# Altium schematic sheet Sheet4.SchDoc
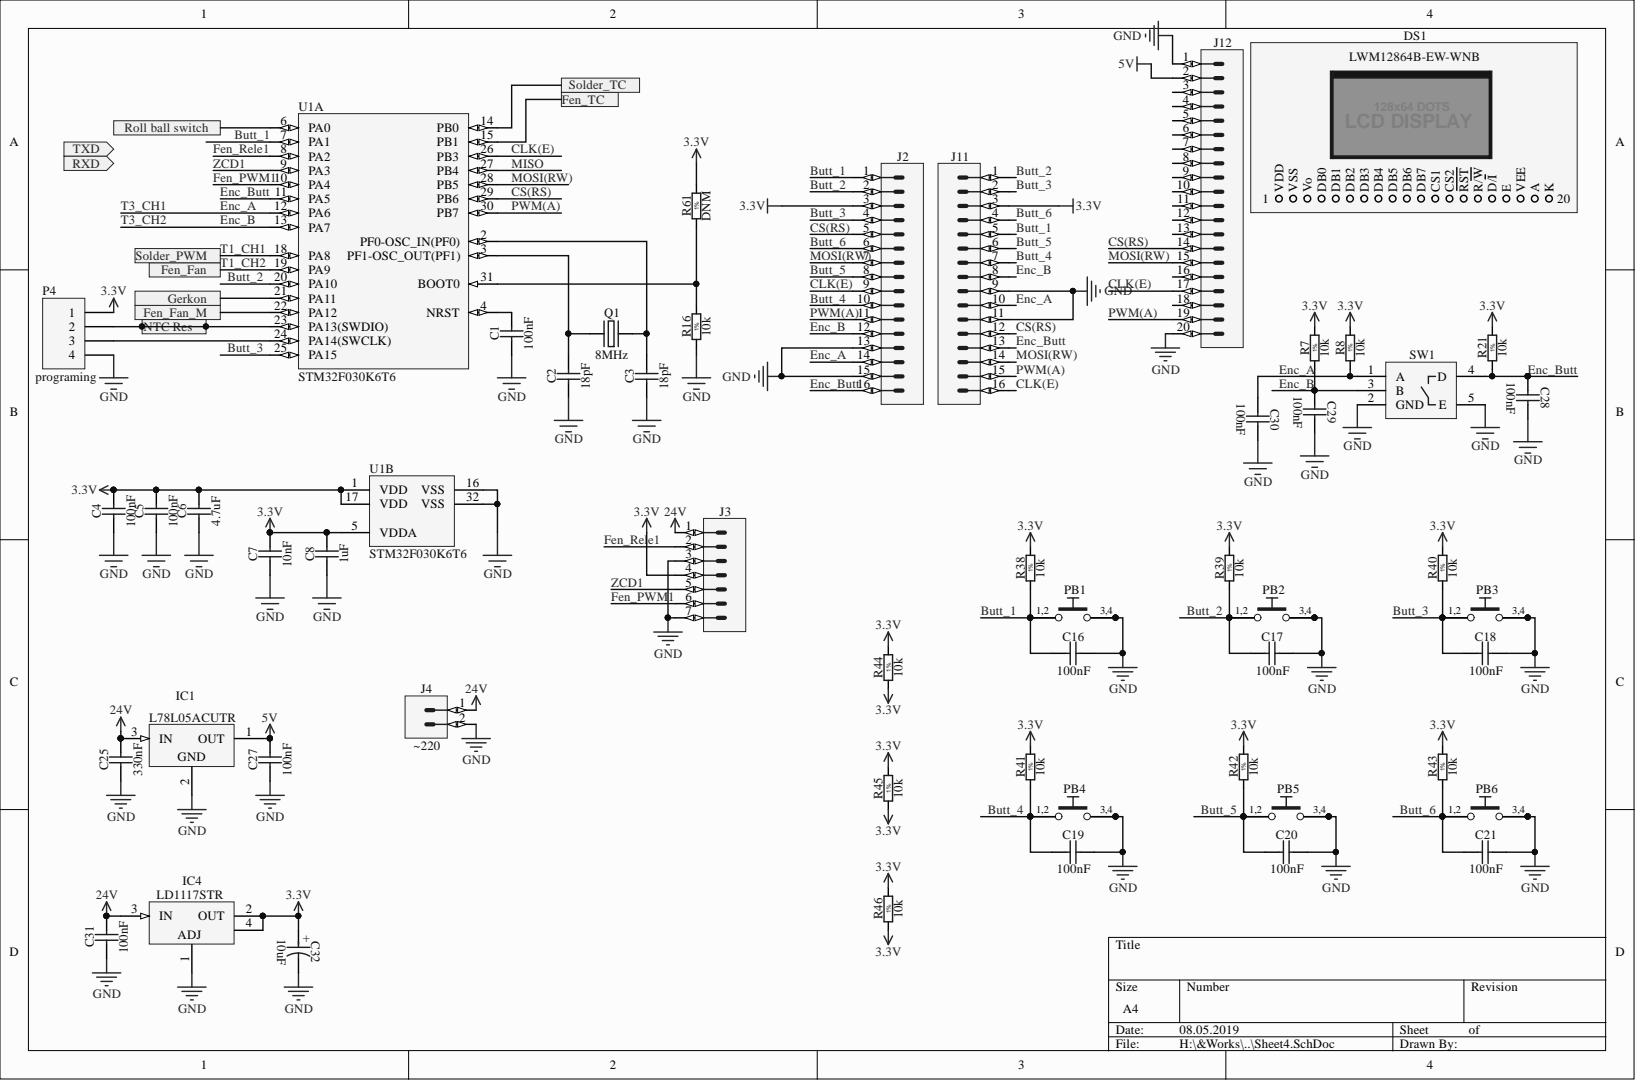
[diagram p0: Sheet4.SchDoc]

## Parts
C1  100nF  [CAPC1608X87N]
C2  18pF  [CAPC2012X135N]
C3  18pF  [CAPC2012X135N]
C4  100nF  [CAPC1608X87N]
C5  100nF  [CAPC1608X87N]
C6  4.7uF  [CAPC1608X87N]
C7  10nF  [CAPC1608X87N]
C8  1uF  [CAPC1608X87N]
C16  100nF  [CAPC2012X135N]
C17  100nF  [CAPC2012X135N]
C18  100nF  [CAPC2012X135N]
C19  100nF  [CAPC2012X135N]
C20  100nF  [CAPC2012X135N]
C21  100nF  [CAPC2012X135N]
C25  330nF  [CAPC2012X145N]
C27  100nF  [CAPC2013X145N]
C28  100nF  [CAPC2012X135N]
C29  100nF  [CAPC2012X135N]
C30  100nF  [CAPC2012X135N]
C31  100nF  [CAPC2013X145N]
C32  10uF  [CAPAE430X540N]
DS1  LWM12864B-EW-WNB  [DS_DENSITRON_LWM12864B-EW-WNB]
IC1  L78L05ACUTR  [SOT89]
IC4  LD1117STR  [SOT230P700X180-4N]
J2  Header 16  [3M_N2516-6002RB]  (value not drawn)
J3  KK®  [MOLEX_22-04-1071]  (value not drawn)
J4  ~220  [PHOENIX_1755736]
J11  Header 16  [3M_N2516-6002RB]  (value not drawn)
J12  =Family  [HARWIN_D01-9922046]
P4  programing  [HDR1X4]
PB1  Push Button  [PB_TYCO_FSM2JSMA]  (value not drawn)
PB2  Push Button  [PB_TYCO_FSM2JSMA]  (value not drawn)
PB3  Push Button  [PB_TYCO_FSM2JSMA]  (value not drawn)
PB4  Push Button  [PB_TYCO_FSM2JSMA]  (value not drawn)
PB5  Push Button  [PB_TYCO_FSM2JSMA]  (value not drawn)
PB6  Push Button  [PB_TYCO_FSM2JSMA]  (value not drawn)
Q1  8MHz  [XTAL_CITIZEN_HCM49]
R7  10k  [RESC2012X60N]
R8  10k  [RESC2012X60N]
R16  10k  [RESC1608X55N]
R21  10k  [RESC2012X60N]
R38  10k  [RESC2012X60N]
R39  10k  [RESC2012X60N]
R40  10k  [RESC2012X60N]
R41  10k  [RESC2012X60N]
R42  10k  [RESC2012X60N]
R43  10k  [RESC2012X60N]
R44  10k  [RESC2012X60N]
R45  10k  [RESC2012X60N]
R46  10k  [RESC2012X60N]
R61  DNM  [RESC2012X60N]
SW1  Encoder  [Encoder_DIP]  (value not drawn)
U1  STM32F030K6T6  [STM-LQFP32_N]  (parts A,B)

## Ports
Fen_Fan, Fen_Fan_M, Fen_TC, Gerkon, NTC Res, Roll ball switch, RXD, Solder_PWM, Solder_TC, TXD

## Nets
3.3V: C4.2 C5.2 C6.2 C7.2 C8.2 C32.1 IC4.2(OUT) IC4.4 J2.3 J3.4 J11.3 P4.1 R7.2 R8.2 R21.2 R38.2 R39.2 R40.2 R41.2 R42.2 R43.2 R44.1 R44.2 R45.1 R45.2 R46.1 R46.2 R61.2 U1.1(VDD) U1.5(VDDA) U1.17(VDD)
5V: C27.2 IC1.1 J12.2
24V: C25.2 C31.2 IC1.3 IC4.3(IN) J3.1 J4.1
GND: C1.1 C2.1 C3.1 C4.1 C5.1 C6.1 C7.1 C8.1 C16.2 C17.2 C18.2 C19.2 C20.2 C21.2 C25.1 C27.1 C28.2 C29.2 C30.2 C31.1 C32.2 IC1.2 IC4.1 J2.13 J2.15 J3.3 J3.7 J4.2 J11.9 J11.11 J12.1 J12.20 P4.4 PB1.3 PB1.4 PB2.3 PB2.4 PB3.3 PB3.4 PB4.3 PB4.4 PB5.3 PB5.4 PB6.3 PB6.4 R16.1 SW1.2(GND) SW1.5(E) U1.16(VSS) U1.32(VSS)
Butt_1: C16.1 J2.1 J11.5 PB1.1 PB1.2 R38.1 U1.7(PA1)
Butt_2: C17.1 J2.2 J11.1 PB2.1 PB2.2 R39.1 U1.20(PA10)
Butt_3: C18.1 J2.4 J11.2 PB3.1 PB3.2 R40.1 U1.25(PA15)
Butt_4: C19.1 J2.10 J11.7 PB4.1 PB4.2 R41.1
Butt_5: C20.1 J2.8 J11.6 PB5.1 PB5.2 R42.1
Butt_6: C21.1 J2.6 J11.4 PB6.1 PB6.2 R43.1
CLK(E): J2.9 J11.16 J12.17 U1.26(PB3)
CS(RS): J2.5 J11.12 J12.14 U1.29(PB6)
Enc_A: C30.1 J2.14 J11.10 R8.1 SW1.1(A) U1.12(PA6)
Enc_B: C29.1 J2.12 J11.8 R7.1 SW1.3(B) U1.13(PA7)
Enc_Butt: C28.1 J2.16 J11.13 R21.1 SW1.4(D) U1.11(PA5)
Fen_Fan_M: U1.22(PA12) ; port Fen_Fan_M
Fen_PWM1: J3.6 U1.10(PA4)
Fen_Rele1: J3.2 U1.8(PA2)
Fen_TC: U1.15(PB1) ; port Fen_TC
Gerkon: U1.21(PA11) ; port Gerkon
MISO: U1.27(PB4)
MOSI(RW): J2.7 J11.14 J12.15 U1.28(PB5)
NTC Res: P4.2 U1.23(PA13(SWDIO)) ; port NTC Res
PWM(A): J2.11 J11.15 J12.19 U1.30(PB7)
Roll ball switch: U1.6(PA0) ; port Roll ball switch
Solder_TC: U1.14(PB0) ; port Solder_TC
T1_CH1: U1.18(PA8) ; port Solder_PWM
T1_CH2: U1.19(PA9) ; port Fen_Fan
ZCD1: J3.5 U1.9(PA3)
NetC1_2: C1.2 U1.4(NRST)
NetC2_2: C2.2 Q1.1 U1.3(PF1-OSC_OUT(PF1))
NetC3_2: C3.2 Q1.2 U1.2(PF0-OSC_IN(PF0))
NetP4_3: P4.3 U1.24(PA14(SWCLK))
NetR16_2: R16.2 R61.1 U1.31(BOOT0)
N00097: ; port RXD
N00098: ; port TXD

Not connected: J12 3 4 5 6 7 8 9 10 11 12 13 16 18

## Library data of the parts
C1 C4 C5 = CC0603_100NF_50V_10%_X7R: Non-polarised capacitor; Manufacturer: GENERIC; Manufacturer1 Part Number: 223858615649; Part Description: SMD Multilayer Chip Ceramic Capacitor; Database Table Name: Capacitors SMD; TC: X7R; Tolerance: ±10%; Voltage: 50V; Case: 0603; Component Kind: Standard; Component Type: Standard; CreateDate: 03.12.2007; HelpURL: \\cern.ch\dfs\Applications\Altium\Datasheets\CC0603_X7R_PHYCOMP.pdf; LatestRevisionDate: 03.12.2007; Library Name: Capacitors.DbLib; Manufacturer1 ComponentHeight: 0.87mm; Manufacturer1 Example: PHYCOMP; Manufacturer Part Number: CC0603_100NF_50V_10%_X7R; Mounted: Yes; Part Number: CC0603_100NF_50V_10%_X7R; Pin Count: 2; Sense: No; SMD: Yes; Socket: No; Status: Preferred; Value: 100nF; Author: CERN DEM JLC
C2 C3 = CC0805_18PF_50V_5%_NP0: Non-polarised capacitor; Manufacturer: GENERIC; Manufacturer1 Part Number: 223886115189; Part Description: SMD Multilayer Chip Ceramic Capacitor; Database Table Name: Capacitors SMD; TC: C0G/NP0; Tolerance: ±5%; Voltage: 50V; Case: 0805; Component Kind: Standard; Component Type: Standard; CreateDate: 03.12.2007; HelpURL: \\cern.ch\dfs\Applications\Altium\Datasheets\CC0805_NP0_PHYCOMP.pdf; LatestRevisionDate: 03.12.2007; Library Name: Capacitors.DbLib; Manufacturer1 ComponentHeight: 1.35mm; Manufacturer1 Example: PHYCOMP; Manufacturer Part Number: CC0805_18PF_50V_5%_NP0; Mounted: Yes; Part Number: CC0805_18PF_50V_5%_NP0; Pin Count: 2; Sense: No; SMD: Yes; Socket: No; Status: Preferred; Value: 18pF; Author: CERN DEM JLC
C6 = CC0603_4.7UF_6.3V_10%_X5R: Non-polarised capacitor; Manufacturer: GENERIC; Manufacturer1 Part Number: 225520613672; Part Description: SMD Multilayer Chip Ceramic Capacitor; Database Table Name: Capacitors SMD; TC: X5R; Tolerance: ±10%; Voltage: 6.3V; Case: 0603; Component Kind: Standard; Component Type: Standard; CreateDate: 03.12.2007; HelpURL: \\cern.ch\dfs\Applications\Altium\Datasheets\CC0805_X5R_PHYCOMP_HC.pdf; LatestRevisionDate: 03.12.2007; Library Name: Capacitors.DbLib; Manufacturer1 ComponentHeight: 0.87mm; Manufacturer1 Example: PHYCOMP; Manufacturer Part Number: CC0603_4.7uF_6.3V_10%_X5R; Mounted: Yes; Part Number: CC0603_4.7UF_6.3V_10%_X5R; Pin Count: 2; Sense: No; SMD: Yes; Socket: No; Status: Preferred; Value: 4.7uF; Author: CERN DEM JLC
C7 = CC0603_10NF_50V_10%_X7R: Non-polarised capacitor; Manufacturer: GENERIC; Manufacturer1 Part Number: 223858615636; Part Description: SMD Multilayer Chip Ceramic Capacitor; Database Table Name: Capacitors SMD; TC: X7R; Tolerance: ±10%; Voltage: 50V; Case: 0603; Component Kind: Standard; Component Type: Standard; CreateDate: 03.12.2007; HelpURL: \\cern.ch\dfs\Applications\Altium\Datasheets\CC0603_X7R_PHYCOMP.pdf; LatestRevisionDate: 03.12.2007; Library Name: Capacitors.DbLib; Manufacturer1 ComponentHeight: 0.87mm; Manufacturer1 Example: PHYCOMP; Manufacturer Part Number: CC0603_10NF_50V_10%_X7R; Mounted: Yes; Part Number: CC0603_10NF_50V_10%_X7R; Pin Count: 2; Sense: No; SMD: Yes; Socket: No; Status: Preferred; Value: 10nF; Author: CERN DEM JLC
C8 = CC0603_1UF_16V_10%_X7R: Non-polarised capacitor; Manufacturer: GENERIC; Manufacturer1 Part Number: 223878615663; Part Description: SMD Multilayer Chip Ceramic Capacitor; Database Table Name: Capacitors SMD; TC: X7R; Tolerance: ±10%; Voltage: 16V; Case: 0603; Component Kind: Standard; Component Type: Standard; CreateDate: 03.12.2007; HelpURL: \\cern.ch\dfs\Applications\Altium\Datasheets\CC0603_X7R_PHYCOMP.pdf; LatestRevisionDate: 17.04.2015; Library Name: Capacitors.DbLib; Manufacturer1 ComponentHeight: 0.9mm; Manufacturer1 Example: YAGEO PHYCOMP; Manufacturer Part Number: CC0603_1uF_16V_10%_X7R; Mounted: Yes; Part Number: CC0603_1UF_16V_10%_X7R; Pin Count: 2; Sense: No; SMD: Yes; Socket: No; Status: None; Value: 1uF; Author: CERN DEM JLC
C16-C21 C28-C30 = CC0805_100NF_50V_10%_X7R: Non-polarised capacitor; Manufacturer: GENERIC; Manufacturer1 Part Number: C2012X7R1H104K085AA; Part Description: SMD Multilayer Chip Ceramic Capacitor; Database Table Name: Capacitors SMD; TC: X7R; Tolerance: ±10%; Voltage: 50V; Case: 0805; Component Kind: Standard; Component Type: Standard; CreateDate: 03.12.2007; HelpURL: \\cern.ch\dfs\Applications\Altium\Datasheets\CC0805_X7R_TDK_C.pdf; LatestRevisionDate: 22.04.2015; Library Name: Capacitors.DbLib; Manufacturer1 ComponentHeight: 1mm; Manufacturer1 Example: TDK; Manufacturer Part Number: CC0805_100NF_50V_10%_X7R; Mounted: Yes; Part Number: CC0805_100NF_50V_10%_X7R; Pin Count: 2; Sense: No; SMD: Yes; Socket: No; Status: Preferred; Value: 100nF; Author: CERN DEM JLC
C25 = CC0805_330NF_50V_20%_X5R: Non-polarised capacitor; Manufacturer: GENERIC; Manufacturer1 Part Number: C2012X5R1H334M125AA; Part Description: SMD Multilayer Chip Ceramic Capacitor; Database Table Name: Capacitors SMD; TC: X5R; Tolerance: ±20%; Voltage: 50V; Case: 0805; Component Kind: Standard; Component Type: Standard; CreateDate: 16.09.2016; HelpURL: \\cern.ch\dfs\Applications\Altium\Datasheets\CC0805_X5R_TDK_C.pdf; LatestRevisionDate: 16.09.2016; Library Name: Capacitors.DbLib; Manufacturer1 ComponentHeight: 1.45mm; Manufacturer1 Example: TDK; Manufacturer Part Number: CC0805_330NF_50V_20%_X5R; Mounted: Yes; Part Number: CC0805_330NF_50V_20%_X5R; Pin Count: 2; Sense: No; SMD: Yes; Socket: No; Status: Preferred; Value: 330nF; Author: CERN DEM MR
C27 C31 = CC0805_100NF_50V_10%_X7R_VISHAY_VJ_NON-MAGNETIC: Non-polarised capacitor; Manufacturer: VISHAY VITRAMON; Manufacturer Part Number: VJ0805Y104KCAA; Part Description: Surface Mount Multilayer Ceramic Chip Capacitors for Non-Magnetic Applications (IR Reflow Soldering); Database Table Name: Capacitors SMD; TC: X7R; Tolerance: ±10%; Voltage: 50V; Case: 0805; ComponentHeight: 1.45mm; Component Kind: Standard; Component Type: Standard; CreateDate: 22.06.2016; HelpURL: \\cern.ch\dfs\Applications\Altium\Datasheets\CC0805_VISHAY_VJ_NON-MAGNETIC.pdf; LatestRevisionDate: 22.06.2016; Library Name: Capacitors.DbLib; Mounted: Yes; Part Number: CC0805_100NF_50V_10%_X7R_VISHAY_VJ_NON-MAGNETIC; Pin Count: 2; Sense: No; SMD: Yes; Socket: No; Status: None; Value: 100nF; Author: CERN DEM MR
C32 = CAE430-540_10UF_16V_20%: Polarised capacitor; Manufacturer: PANASONIC; Manufacturer Part Number: EEEFK1C100AR; Part Description: Aluminum Electrolytic SMT Capacitor; Database Table Name: Capacitors SMD; Tolerance: ±20%; Voltage: 16V; Case: B; ComponentHeight: 5.8mm; Component Kind: Standard; Component Type: Standard; CreateDate: 03.12.2007; HelpURL: \\cern.ch\dfs\Applications\Altium\Datasheets\CAE_PANASONIC_FK.pdf; LatestRevisionDate: 05.11.2014; Library Name: Capacitors.DbLib; Mounted: Yes; Part Number: CAE430-540_10UF_16V_20%; Pin Count: 2; Sense: No; SMD: Yes; Socket: No; Status: Not Recommended; Value: 10uF; Author: CERN DEM JLC
DS1 = DS_DENSITRON_LWM12864B-EW-WNB: LCD Display Module, 128x64 Dots,Transmissive Negative, BL White LED, Wide Temp, Negative Voltage Generator, STN Blue; Bonding: No; Database Table Name: LEDs & Displays; PressFit: No; ComponentHeight: 8.9mm; Component Kind: Standard; Component Type: Standard; CreateDate: 09.05.2011; Device: LWM12864B-EW-WNB; HelpURL: \\cern.ch\dfs\Applications\Altium\Datasheets\DS_DENSITRON_LWM12864B-EW-WNB.pdf; LatestRevisionDate: 09.05.2011; Library Name: ICs And Semiconductors.DbLib; Manufacturer: DENSITRON; Manufacturer Part Number: LWM12864B-EW-WNB; Mounted: Yes; PackageDescription: LCD Display Module, 128x64 Dots,Transmissive Negative, BL White LED, Wide Temp, Negative Voltage Generator, STN Blue; Part Description: LCD Display Module, 128x64 Dots,Transmissive Negative, BL White LED, Wide Temp, Negative Voltage Generator, STN Blue; Part Number: DS_DENSITRON_LWM12864B-EW-WNB; Pin Count: 0; Sense: No; SMD: No; Socket: No; Author: CERN DEM JLC
IC1 = L78L05ACUTR: 3-Terminal Regulator 1=OUT 2=GND 3=IN; Manufacturer: ST MICROELECTRONICS; Part Description: 5V 100mA Positive Voltage Regulator; Bonding: No; Database Table Name: Regulators; PressFit: No; Case: SOT89; ComponentHeight: 1.6mm; Component Kind: Standard; Component Type: Standard; CreateDate: 10.10.2011; Device: L78L05ACUTR; HelpURL: \\cern.ch\dfs\Applications\Altium\Datasheets\L78L05ACUTR.pdf; LatestRevisionDate: 06.12.2011; Library Name: ICs And Semiconductors.DbLib; Manufacturer Part Number: L78L05ACUTR; Mounted: Yes; PackageDescription: SOT89-3, 3-Leads, Body 2.44x4.5mm; Part Number: L78L05ACUTR; Pin Count: 4; Sense: No; SMD: Yes; Socket: No; Author: CERN DEM JLC
IC4 = LD1117STR: 3-Terminal Regulator 1=Adj 2=OUT 3=IN 4=OUT; Manufacturer: ST MICROELECTRONICS; Part Description: 1.25V To 15V Adjustable, 800mA Low-Dropout Positive Voltage Regulator; Bonding: No; Database Table Name: Regulators; PressFit: No; Case: SOT223; ComponentHeight: 1.8mm; Component Kind: Standard; Component Type: Standard; CreateDate: 14.07.2016; Device: LD1117STR; HelpURL: \\cern.ch\dfs\Applications\Altium\Datasheets\LD1117STR.pdf; LatestRevisionDate: 14.07.2016; Library Name: ICs And Semiconductors.DbLib; Manufacturer Part Number: LD1117STR; Mounted: Yes; PackageDescription: SOT223, 2.30mm pitch; 3 pin, 3.70mm W X 6.70mm L, IPC Medium Density; Part Number: LD1117STR; Pin Count: 4; Sense: No; SMD: Yes; Socket: No; Author: CERN DEM MR
J2 J11 = 3M_N2516-6002RB: Connector 16 Male; Part Description: 16 Contacts - Straight .100'' x .100'' Low Profile Four-Wall Header, 2500 Series; Database Table Name: 3M; PressFit: No; ComponentHeight: 10.03mm; Component Kind: Standard; Component Type: Standard; CreateDate: 11.02.2009; Family: Header 16; HelpURL: \\cern.ch\dfs\Applications\Altium\Datasheets\3M_N2516-6002RB.pdf; LatestRevisionDate: 11.08.2009; Library Name: Connectors.DbLib; Manufacturer: 3M; Manufacturer Part Number: N2516-6002RB; Mounted: Yes; Part Number: 3M_N2516-6002RB; Pin Count: 16; Sense: No; SMD: No; Socket: No; Status: None; Author: CERN DEM JLC
J3 = MOLEX_22-04-1071: Connector 7 Male; Part Description: 2.5mm (.100") Pitch KK® Wire-to-Board Header, Vertical, with Friction Lock, 7 Circuits, Tin (Sn) Plating; Database Table Name: MOLEX; PressFit: No; ComponentHeight: 11.7mm; Component Kind: Standard; Component Type: Standard; CreateDate: 17.06.2013; Family: KK®; HelpURL: \\cern.ch\dfs\Applications\Altium\Datasheets\MOLEX_22-04-1071.pdf; LatestRevisionDate: 17.06.2013; Library Name: Connectors.DbLib; Manufacturer: MOLEX; Manufacturer Part Number: 22-04-1071; Mounted: Yes; Part Number: MOLEX_22-04-1071; Pin Count: 7; Sense: No; SMD: No; Socket: No; Status: None; Author: CERN DEM JLC
J4 = PHOENIX_1755736: Connector 2 Male; Manufacturer: PHOENIX CONTACT; Manufacturer Part Number: 1755736 (MSTBVA 2,5/2-G-5,08); Part Description: 12A, 250V, Pitch 5.08mm, 2 Positions Header; PressFit: No; ComponentHeight: 12mm; Component Kind: Standard; Component Type: Standard; CreateDate: 07.12.2010; Database Table Name: PHOENIX; HelpURL: \\cern.ch\dfs\Applications\Altium\Datasheets\PHOENIX_1755736.pdf; LatestRevisionDate: 07.12.2010; Library Name: Connectors.DbLib; Mounted: Yes; Part Number: PHOENIX_1755736; Pin Count: 2; Sense: No; SMD: No; Socket: No; Status: None; Author: CERN DEM JLC
J12 = HARWIN_D01-9922046: Connector 20 Male; Part Description: 20 Contact 2.54mm Pitch Single-In-Line Header Strip -  Top Pin Ø0.46mm - Bottom Pin Ø0.69mm; Database Table Name: HARWIN; PressFit: No; ComponentHeight: 7.43mm; Component Kind: Standard; Component Type: Standard; CreateDate: 08.12.2008; HelpURL: \\cern.ch\dfs\Applications\Altium\Datasheets\HARWIN_D01-9922046.pdf; LatestRevisionDate: 08.12.2008; Library Name: Connectors.DbLib; Manufacturer: HARWIN; Manufacturer Part Number: D01-9922046; Mounted: Yes; Part Number: HARWIN_D01-9922046; Pin Count: 20; Sense: No; SMD: No; Socket: No; Status: None; Author: CERN DEM JLC
P4 = Header 4: Header, 4-Pin; Component Kind: Standard; LatestRevisionDate: 17-Jul-2002; LatestRevisionNote: Re-released for DXP Platform.; Library Name: Miscellaneous Connectors.IntLib; Signal Integrity: Connector; Publisher: Altium Limited
PB1-PB6 = PB_TYCO_FSM2JSMA: Push-Button Switch SPDT; Manufacturer: TYCO ELECTRONICS; Manufacturer Part Number: FSM2JSMA or  2-1437565-7; Part Description: 12VDC 50mA SPST Tactile  Push-Button Switch; Database Table Name: Switches; PressFit: No; ComponentHeight: 4.59mm; Component Kind: Standard; Component Type: Standard; CreateDate: 08.09.2008; Family: Push Button; HelpURL: \\cern.ch\dfs\Applications\Altium\Datasheets\PB_TYCO_FSM2JSMA.pdf; LatestRevisionDate: 21.06.2012; Library Name: Eletro-mechanical.DbLib; Mounted: Yes; PackageDescription: Body 6mm x 6mm, Surface Mount Tactile Switches, TYCO ALCOSWITCH FSMJSMA Series; Part Number: PB_TYCO_FSM2JSMA; Pin Count: 4; Sense: No; SMD: Yes; Socket: No; Status: None; Author: CERN DEM JLC
Q1 = XTAL_24MHZ_CITIZEN_HCM49-24.000MABJT: Quartz Crystal; Manufacturer: CITIZEN FINETECH MIYOTA CO; Part Description: Tolerance:± 30ppm, Stability: ± 50ppm, -10°C to +60°C, 18pF, AT-CUT Crystal Unit, HCM49 Series; Bonding: No; Database Table Name: Crystals & Oscillators; PressFit: No; ComponentHeight: 4.3mm; Component Kind: Standard; Component Type: Standard; CreateDate: 22.07.2014; HelpURL: \\cern.ch\dfs\Applications\Altium\Datasheets\XTAL_CITIZEN_HCM49.pdf; LatestRevisionDate: 22.07.2014; Library Name: ICs And Semiconductors.DbLib; Manufacturer Part Number: HCM49-24.000MABJT; Mounted: Yes; PackageDescription: CITIZEN HCM49 Case, SMD Cristal, Body 11.4 x 4.7mm; Part Number: XTAL_24MHZ_CITIZEN_HCM49-24.000MABJT; Pin Count: 2; Sense: No; SMD: No; Socket: No; Value: 8MHz; Author: CERN DEM JLC
R7 R8 R21 R38-R46 R61 = R0805_10K_1%_0.125W_100PPM: Resistor - 1%; Manufacturer: GENERIC; Manufacturer1 Part Number: NRC10F1002TRF; Part Description: General Purpose Thick Film Chip Resistor; Database Table Name: Resistors SMD; Power: 0.125W; PressFit: No; TC: ±100ppm/°C; Case: 0805; Component Kind: Standard; Component Type: Standard; CreateDate: 03.12.2007; HelpURL: \\cern.ch\dfs\Applications\Altium\Datasheets\R0805_NIC_NRC.pdf; LatestRevisionDate: 13.03.2008; Library Name: Resistors.DbLib; Manufacturer1 ComponentHeight: 0.6mm; Manufacturer1 Example: NIC COMPONENT; Manufacturer Part Number: R0805_10K_1%_0.125W_100PPM; Mounted: Yes; Part Number: R0805_10K_1%_0.125W_100PPM; Pin Count: 2; Sense: No; SMD: Yes; Socket: No; Status: Preferred; Tolerance: ±1%; Author: CERN DEM JLC
  R7 R8 R21 R38-R46: Value: 10k
  R61: Value: DNM
R16 = R0603_10K_1%_0.1W_100PPM: Resistor - 1%; Manufacturer: GENERIC; Manufacturer1 Part Number: NRC06F1002TRF; Part Description: General Purpose Thick Film Chip Resistor; Database Table Name: Resistors SMD; Power: 0.1W; PressFit: No; TC: ±100ppm/°C; Case: 0603; Component Kind: Standard; Component Type: Standard; CreateDate: 03.12.2007; HelpURL: \\cern.ch\dfs\Applications\Altium\Datasheets\R0603_NIC_NRC.pdf; LatestRevisionDate: 13.03.2008; Library Name: Resistors.DbLib; Manufacturer1 ComponentHeight: 0.55mm; Manufacturer1 Example: NIC COMPONENT; Manufacturer Part Number: R0603_10K_1%_0.1W_100PPM; Mounted: Yes; Part Number: R0603_10K_1%_0.1W_100PPM; Pin Count: 2; Sense: No; SMD: Yes; Socket: No; Status: Preferred; Tolerance: ±1%; Value: 10k; Author: CERN DEM JLC
SW1 = SW_ALPS_EC11B15242AE: Encoder, 30-Detent, 15-Pulse, Horizontal With Push-on Switch; Component Kind: Standard; Value: Encoder; Library Name: Encoders.SchLib
U1 = STM32F030K6T6: ARM Cortex-M0 32-bit MCU, 32 KB Flash, 4 KB Internal RAM, 26 I/Os, 32-pin LQFP, -40 to 85 degC, Tray; FLASH Size (Prog) (kB): 32; I/Os (High Current): 26; Internal RAM Size (kB): 4; Operating Frequency(F) (Processor speed) (MHz): 48; Supply Voltage(Vcc) min (V): 2.4; Component Kind: Standard; ComponentLink1Description: Manufacturer URL; ComponentLink1URL: http://www.st.com/web/en/home.html; ComponentLink2Description: Datasheet; ComponentLink2URL: http://www.st.com/st-web-ui/static/active/en/resource/technical/document/datasheet/DM00088500.pdf; ComponentLink3Description: Package Specification; ComponentLink3URL: http://www.st.com/st-web-ui/static/active/en/resource/technical/document/datasheet/DM00088500.pdf; Core: ARM Cortex-M0; DatasheetVersion: Rev. 1; Library Name: STMicroelectronics STM32 F0.IntLib; Mounting Technology: Surface Mount; PackageDescription: 32-Pin Low Profile Quad Flat Package, 7 x 7 mm Body, 0.8 mm Pitch; PackageReference: LQFP32; PackageVersion: Rev. 1, 07/2013; Packing: Tray; PartNumber: STM32F030K6T6; Supplier 1: Digi-Key; Supplier 2: Newark; Supplier 3: Mouser; Supplier Part Number 1: 497-14046-ND; Supplier Part Number 2: 19X6194; Supplier Part Number 3: 511-STM32F030K6T6
